# Altium schematic sheet PerifericaRelè.SchDoc(PerifericaRelè)
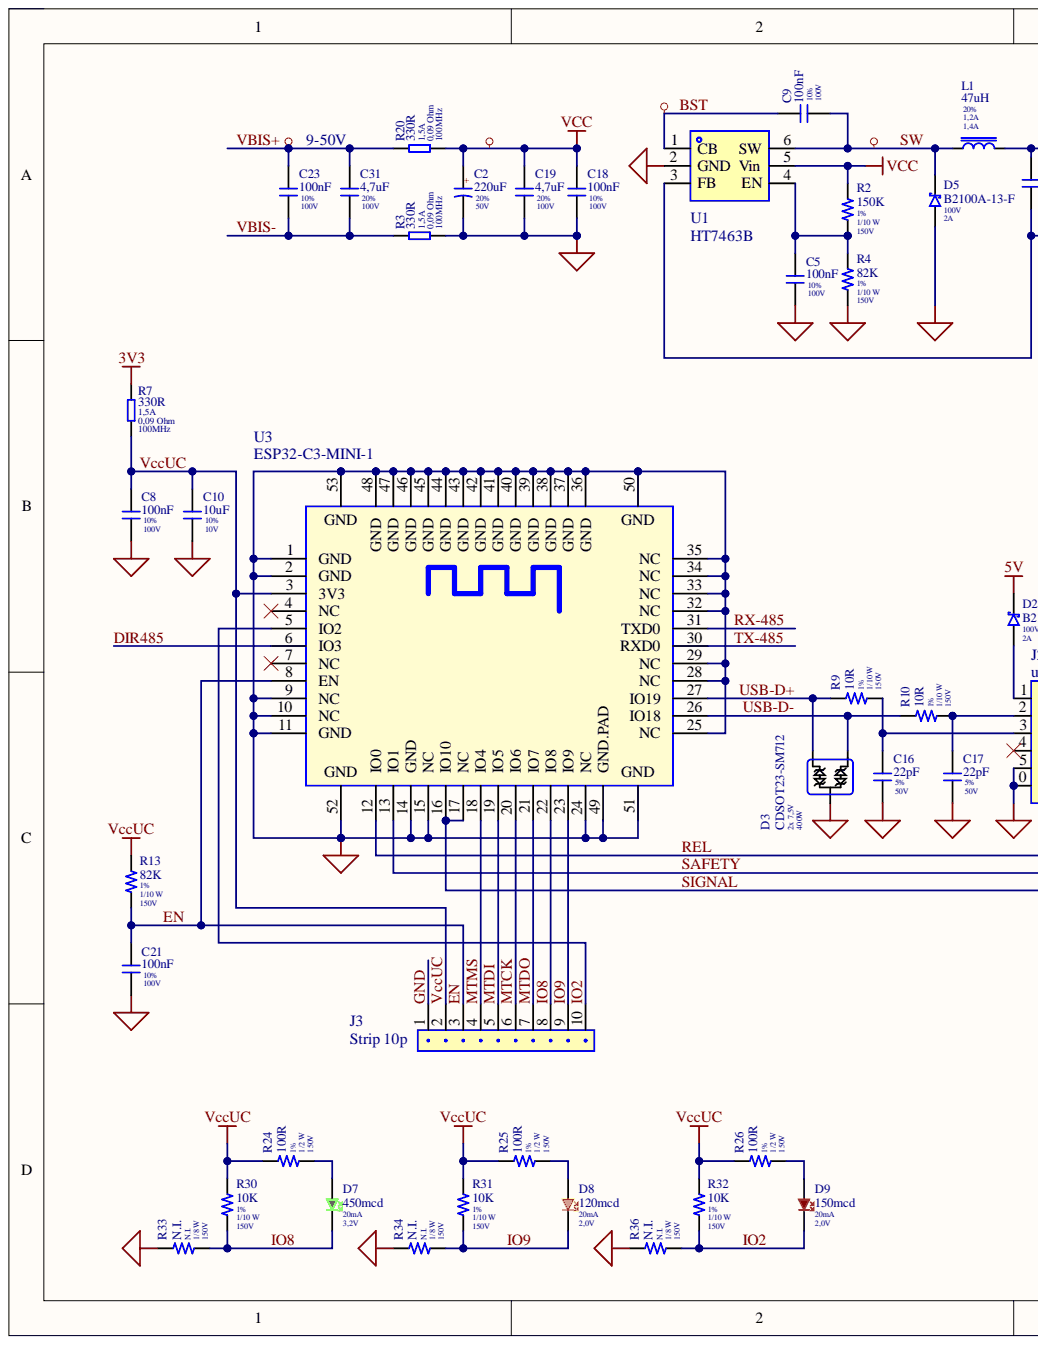
[diagram p0: PerifericaRelè.SchDoc, left]
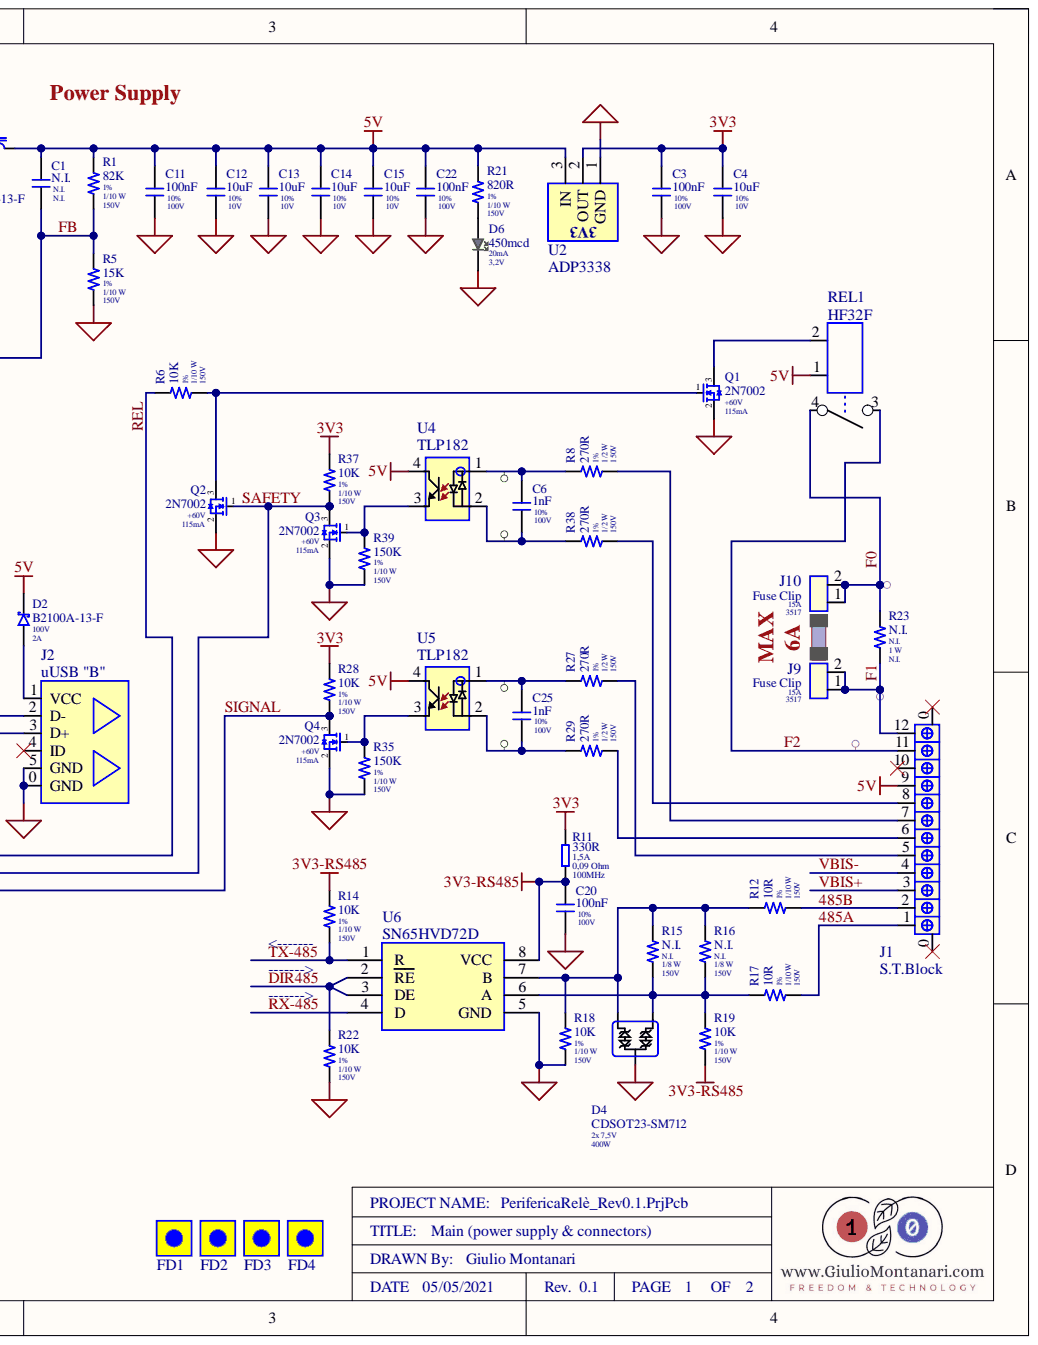
[diagram p1: PerifericaRelè.SchDoc, right]

## Parts
C1  N.I.  [CAP_0805]
C2  220uF  Tolerance: 20%  Voltage: 50V  [CAP_F_D8.0H10]
C3  100nF  Tolerance: 10%  Voltage: 100V  [CAP_0805]
C4  10uF  Tolerance: 10%  Voltage: 10V  [CAP_0805]
C5  100nF  Tolerance: 10%  Voltage: 100V  [CAP_0805]
C6  1nF  Tolerance: 10%  Voltage: 100V  [CAP_0805]
C8  100nF  Tolerance: 10%  Voltage: 100V  [CAP_0805]
C9  100nF  Tolerance: 10%  Voltage: 100V  [CAP_0805]
C10  10uF  Tolerance: 10%  Voltage: 10V  [CAP_0805]
C11  100nF  Tolerance: 10%  Voltage: 100V  [CAP_0805]
C12  10uF  Tolerance: 10%  Voltage: 10V  [CAP_0805]
C13  10uF  Tolerance: 10%  Voltage: 10V  [CAP_0805]
C14  10uF  Tolerance: 10%  Voltage: 10V  [CAP_0805]
C15  10uF  Tolerance: 10%  Voltage: 10V  [CAP_0805]
C16  22pF  Tolerance: 5%  Voltage: 50V  [CAP_0805]
C17  22pF  Tolerance: 5%  Voltage: 50V  [CAP_0805]
C18  100nF  Tolerance: 10%  Voltage: 100V  [CAP_0805]
C19  4,7uF  Tolerance: 20%  Voltage: 100V  [CAP_1210]
C20  100nF  Tolerance: 10%  Voltage: 100V  [CAP_0805]
C21  100nF  Tolerance: 10%  Voltage: 100V  [CAP_0805]
C22  100nF  Tolerance: 10%  Voltage: 100V  [CAP_0805]
C23  100nF  Tolerance: 10%  Voltage: 100V  [CAP_0805]
C25  1nF  Tolerance: 10%  Voltage: 100V  [CAP_0805]
C31  4,7uF  Tolerance: 20%  Voltage: 100V  [CAP_1210]
D2  B2100A-13-F  Current MAX: 2A  Voltage MAX: 100V  [DO-214AC]
D3  CDSOT23-SM712  Power: 400W  Voltage: 2x 7,5V  [SOT23]
D4  CDSOT23-SM712  [SOT23]
D5  B2100A-13-F  Current MAX: 2A  Voltage MAX: 100V  [DO-214AC]
D6  450mcd  Current: 20mA  Voltage: 3,2V  [LED_G_0805]
D7  450mcd  Current: 20mA  Voltage: 3,2V  [LED_G_0805]
D8  120mcd  Current: 20mA  Voltage: 2,0V  [LED_O_0805]
D9  150mcd  Current: 20mA  Voltage: 2,0V  [LED_R_0805]
FD1  Fiducial  [FIDUCIAL_TL]  (value not drawn)  (no BOM)
FD2  Fiducial  [FIDUCIAL_TL]  (value not drawn)  (no BOM)
FD3  Fiducial  [FIDUCIAL_TL]  (value not drawn)  (no BOM)
FD4  Fiducial  [FIDUCIAL_TL]  (value not drawn)  (no BOM)
J1  S.T.Block  [Screw_TBlock_12PIN_8.26mm_ME]
J2  uUSB "B"  [Micro_USB_B_SMD]
J3  Strip 10p  [STRIP_M_10P_2.54mm]
J9  Fuse Clip  Current: 15A  Man 1 P\N: 3517  [FuseClip_3517]
J10  Fuse Clip  Current: 15A  Man 1 P\N: 3517  [FuseClip_3517]
L1  Irms: 1,2A  Isat: 1,4A  Tolerance: 20%  [IND_6045]
Q1  2N7002  Current MAX: 115mA  Voltage MAX: +60V  [SOT23]
Q2  2N7002  Current MAX: 115mA  Voltage MAX: +60V  [SOT23]
Q3  2N7002  Current MAX: 115mA  Voltage MAX: +60V  [SOT23]
Q4  2N7002  Current MAX: 115mA  Voltage MAX: +60V  [SOT23]
R1  82K  Power Rate: 1/10 W  Tolerance: 1%  Work Voltage: 150V  [RES_0805]
R2  150K  Power Rate: 1/10 W  Tolerance: 1%  Work Voltage: 150V  [RES_0805]
R3  Current: 1,5A  DC Resistance: 0,09 Ohm  FRQ: 100MHz  Inductance: 330R  [FER_0805]  (hidden comment: Ferrite)
R4  82K  Power Rate: 1/10 W  Tolerance: 1%  Work Voltage: 150V  [RES_0805]
R5  15K  Power Rate: 1/10 W  Tolerance: 1%  Work Voltage: 150V  [RES_0805]
R6  10K  Power Rate: 1/10 W  Tolerance: 1%  Work Voltage: 150V  [RES_0805]
R7  Current: 1,5A  DC Resistance: 0,09 Ohm  FRQ: 100MHz  Inductance: 330R  [FER_0805]  (hidden comment: Ferrite)
R8  270R  Power Rate: 1/2 W  Tolerance: 1%  Work Voltage: 150V  [RES_0805]
R9  10R  Power Rate: 1/10 W  Tolerance: 1%  Work Voltage: 150V  [RES_0805]
R10  10R  Power Rate: 1/10 W  Tolerance: 1%  Work Voltage: 150V  [RES_0805]
R11  Current: 1,5A  FRQ: 100MHz  Inductance: 330R  [FER_0805]  (hidden comment: Ferrite)
R12  10R  Power Rate: 1/10 W  Tolerance: 1%  Work Voltage: 150V  [RES_0805]
R13  82K  Power Rate: 1/10 W  Tolerance: 1%  Work Voltage: 150V  [RES_0805]
R14  10K  Tolerance: 1%  Work Voltage: 150V  [RES_0805]
R15  N.I.  Power Rate: 1/8 W  Work Voltage: 150V  [RES_0805]
R16  N.I.  Power Rate: 1/8 W  Work Voltage: 150V  [RES_0805]
R17  10R  Power Rate: 1/10 W  Tolerance: 1%  Work Voltage: 150V  [RES_0805]
R18  10K  Power Rate: 1/10 W  Tolerance: 1%  Work Voltage: 150V  [RES_0805]
R19  10K  Power Rate: 1/10 W  Tolerance: 1%  Work Voltage: 150V  [RES_0805]
R20  Current: 1,5A  DC Resistance: 0,09 Ohm  FRQ: 100MHz  Inductance: 330R  [FER_0805]  (hidden comment: Ferrite)
R21  820R  Tolerance: 1%  Work Voltage: 150V  [RES_0805]
R22  10K  Power Rate: 1/10 W  Tolerance: 1%  Work Voltage: 150V  [RES_0805]
R23  N.I.  Power Rate: 1 W  [RES_2512]
R24  100R  Power Rate: 1/2 W  Tolerance: 1%  Work Voltage: 150V  [RES_0805]
R25  100R  Power Rate: 1/2 W  Tolerance: 1%  Work Voltage: 150V  [RES_0805]
R26  100R  Power Rate: 1/2 W  Tolerance: 1%  Work Voltage: 150V  [RES_0805]
R27  270R  Power Rate: 1/2 W  Tolerance: 1%  Work Voltage: 150V  [RES_0805]
R28  10K  Tolerance: 1%  Work Voltage: 150V  [RES_0805]
R29  270R  Power Rate: 1/2 W  Tolerance: 1%  Work Voltage: 150V  [RES_0805]
R30  10K  Power Rate: 1/10 W  Tolerance: 1%  Work Voltage: 150V  [RES_0805]
R31  10K  Power Rate: 1/10 W  Tolerance: 1%  Work Voltage: 150V  [RES_0805]
R32  10K  Power Rate: 1/10 W  Tolerance: 1%  Work Voltage: 150V  [RES_0805]
R33  N.I.  Power Rate: 1/8 W  Work Voltage: 150V  [RES_0805]
R34  N.I.  Power Rate: 1/8 W  Work Voltage: 150V  [RES_0805]
R35  150K  Power Rate: 1/10 W  Tolerance: 1%  Work Voltage: 150V  [RES_0805]
R36  N.I.  Power Rate: 1/8 W  Work Voltage: 150V  [RES_0805]
R37  10K  Tolerance: 1%  Work Voltage: 150V  [RES_0805]
R38  270R  Power Rate: 1/2 W  Tolerance: 1%  Work Voltage: 150V  [RES_0805]
R39  150K  Power Rate: 1/10 W  Tolerance: 1%  Work Voltage: 150V  [RES_0805]
REL1  HF32F  [REL_184x102h157]
U1  HT7463B  [SOT23-6]
U2  ADP3338  [SOT223]
U3  ESP32-C3-MINI-1  [ESP32-C3-MINI-1]
U4  TLP182  [SOP-4]
U5  TLP182  [SOP-4]
U6  SN65HVD72D  [SO-8]

## Nets
3V3: C3.1 C4.1 R7.1 R11.1 R28.1 R37.1 U2.2
3V3-RS485: C20.1 R11.2 R14.1 R19.2 U6.8(VCC)
5V: C1.1 C11.1 C12.1 C13.1 C14.1 C15.1 C22.1 D2.K J1.9 L1.2 R1.1 R21.1 REL1.1 U2.3 U4.4 U5.4
VCC: C2.1 C18.1 C19.1 R2.1 R20.2 U1.5(Vin)
485A: J1.1 R17.2
485B: J1.2 R12.2
BST: C9.1 U1.1(CB)
DIR485: R22.1 U3.6(IO3) U6.2(RE) U6.3(DE)
EN: C21.1 J3.3 R13.2 U3.8(EN)
F0: J10.1 J10.2 R23.2 REL1.4
F1: J1.12 J9.1 J9.2 R23.1
F2: J1.11 REL1.3
FB: C1.2 R1.2 R5.1 U1.3(FB)
GND: C2.2 C3.2 C4.2 C5.2 C8.2 C10.2 C11.2 C12.2 C13.2 C14.2 C15.2 C16.2 C17.2 C18.2 C19.2 C20.2 C21.2 C22.2 D3.3 D4.3 D5.A D6.K J2.0(GND) J2.5(GND) J3.1 Q1.2 Q2.2 Q3.2 Q4.2 R3.2 R4.2 R5.2 R18.2 R22.2 R33.1 R34.1 R35.2 R36.1 R39.2 U1.2(GND) U2.1 U3.1(GND) U3.2(GND) U3.9(NC) U3.10(NC) U3.11(GND) U3.14(GND) U3.15(NC) U3.24(NC) U3.25(NC) U3.28(NC) U3.29(NC) U3.32(NC) U3.33(NC) U3.34(NC) U3.35(NC) U3.36(GND) U3.37(GND) U3.38(GND) U3.39(GND) U3.40(GND) U3.41(GND) U3.42(GND) U3.43(GND) U3.44(GND) U3.45(GND) U3.46(GND) U3.47(GND) U3.48(GND) U3.49(GND.PAD) U3.50 U3.51 U3.52 U3.53 U6.5(GND)
IO2: D9.K J3.10 R32.2 R36.2 U3.5(IO2)
IO8: D7.K J3.8 R30.2 R33.2 U3.22(IO8)
IO9: D8.K J3.9 R31.2 R34.2 U3.23(IO9)
MTCK: J3.6 U3.20(IO6)
MTDI: J3.5 U3.19(IO5)
MTDO: J3.7 U3.21(IO7)
MTMS: J3.4 U3.18(IO4)
REL: R6.1 U3.12(IO0)
RX-485: U3.31(TXD0) U6.4(D)
SAFETY: Q2.1 Q3.3 R37.2 U3.13(IO1)
SIGNAL: Q4.3 R28.2 U3.16(IO10) U3.17(NC)
SW: C9.2 D5.K L1.1 U1.6(SW)
TX-485: R14.2 U3.30(RXD0) U6.1(R)
USB-D+: D3.1 R9.1 U3.27(IO19)
USB-D-: D3.2 R10.1 U3.26(IO18)
VBIS+: C23.1 C31.1 J1.3 R20.1
VBIS-: C23.2 C31.2 J1.4 R3.1
VccUC: C8.1 C10.1 J3.2 R7.2 R13.1 R24.1 R25.1 R26.1 R30.1 R31.1 R32.1 U3.3(3V3)
NetC5_1: C5.1 R2.2 R4.1 U1.4(EN)
NetC6_1: C6.1 R8.1 U4.1
NetC6_2: C6.2 R38.1 U4.2
NetC16_1: C16.1 J2.3(D+) R9.2
NetC17_1: C17.1 J2.2(D-) R10.2
NetC25_1: C25.1 R27.1 U5.1
NetC25_2: C25.2 R29.1 U5.2
NetD2_A: D2.A J2.1(VCC)
NetD4_1: D4.1 R12.1 R15.1 R16.1 R18.1 U6.7(B)
NetD4_2: D4.2 R15.2 R16.2 R17.1 R19.1 U6.6(A)
NetD6_A: D6.A R21.2
NetD7_A: D7.A R24.2
NetD8_A: D8.A R25.2
NetD9_A: D9.A R26.2
NetJ1_5: J1.5 R27.2
NetJ1_6: J1.6 R29.2
NetJ1_7: J1.7 R8.2
NetJ1_8: J1.8 R38.2
NetQ1_1: Q1.1 Q2.3 R6.2
NetQ1_3: Q1.3 REL1.2
NetQ3_1: Q3.1 R39.1 U4.3
NetQ4_1: Q4.1 R35.1 U5.3

Not connected: J1 0 10; J2 4(ID); U3 4(NC) 7(NC)

## Library data of the parts
C1 = N.I. 0805: Cap N.I. 0805; Database Table Name: Capacitors CERAMIC; Temp Range: -55°C to +125°C; Capacitance: N.I.; Component Kind: Standard; Library Name: Database_Libs.DbLib; Part Number: N.I. 0805; Price 1: 0; Tolerance: N.I.; Voltage: N.I.
C2 = COES 212075010227: Cap Alum Poly 220uF+/-20%; 50V; Case 8,0x10mm; 2000Hrs@105°C; Manufactuer 1: Panasonic; Manufactuer 2: Nichicon; Database Table Name: Capacitors ELECTROLYTIC; Man 1 P\N: EEE-FT1H221AP; Man 2 P\N: UCM1H221MNL1GS; Mounting Method: SMD; Temp Range: -55°C to +105°C; Capacitance: 220uF; Component Kind: Standard; ComponentLink1Description: Data Scheet Panasonic; ComponentLink2Description: Data Scheet Nichicon; Library Name: Database_Libs.DbLib; Part Number: COES 212075010227; Price 1: 0,447; Price 2: 0,488; ComponentLink1URL: C:\Sharing\Lib ALTIUM\DataBaseLib\DataScheet\Condensatori Elettrolitici\Panasonic_CapPoly_SMD_FT-V.pdf; ComponentLink2URL: C:\Sharing\Lib ALTIUM\DataBaseLib\DataScheet\Condensatori Elettrolitici\Nichicon_UCM.pdf
C3 C5 C8 C9 C11 C18 C20-C23 = COCS 557061010104: Cap 100nF+/-10%;  100V; Case 0805; X7R; Manufactuer 1: Samsung; Manufactuer 2: AVX; Manufactuer 3: Walsin; Database Table Name: Capacitors CERAMIC; Man 1 P\N: CL21B104KBCNNNC; Man 2 P\N: 08051C104KAT2A; Man 3 P\N: 0805B104K101CT; Mounting Method: SMD; Temp Range: -55°C to +125°C; Capacitance: 100nF; Component Kind: Standard; ComponentLink1Description: Data Scheet Samsung; ComponentLink2Description: Data Scheet AVX; ComponentLink3Description: Data Scheet Walsin; Library Name: Database_Libs.DbLib; Part Number: COCS 557061010104; Price 1: 0,0092; Price 2: 0,059; Price 3: 0,056; ComponentLink1URL: C:\Sharing\Lib ALTIUM\DataBaseLib\DataScheet\Condensatori Ceramici\Samsung_CL.pdf; ComponentLink2URL: C:\Sharing\Lib ALTIUM\DataBaseLib\DataScheet\Condensatori Ceramici\AVX_X7R.pdf; ComponentLink3URL: C:\Sharing\Lib ALTIUM\DataBaseLib\DataScheet\Condensatori Ceramici\Walsing_MMLC.pdf
C4 C10 C12-C15 = COCS 557061000106: Cap 10uF+/-10%;  10V; Case 0805; X5R; Manufactuer 1: Samsung Electro-Mechanics; Manufactuer 2: Taiyo Yoden  Taiyo Yuden; Manufactuer 3: Walsin; Database Table Name: Capacitors CERAMIC; Man 1 P\N: CL21A106KPFNNNE; Man 2 P\N: LMK212ABJ106KG-T; Man 3 P\N: 0805X106K100CT; Mounting Method: SMD; Temp Range: -55°C to +125°C; Capacitance: 10uF; Component Kind: Standard; ComponentLink1Description: Data Scheet Samsung; ComponentLink2Description: Data Scheet Taiyo Yoden; ComponentLink3Description: Data Scheet Walsin; Library Name: Database_Libs.DbLib; Part Number: COCS 557061000106; Price 1: 0,0139; Price 2: 0,0147; Price 3: 0,0216; ComponentLink1URL: C:\Sharing\Lib ALTIUM\DataBaseLib\DataScheet\Condensatori Ceramici\Samsung_CL.pdf; ComponentLink2URL: C:\Sharing\Lib ALTIUM\DataBaseLib\DataScheet\Condensatori Ceramici\TaiyoYuden_LMK212ABJ106KG-T.pdf; ComponentLink3URL: C:\Sharing\Lib ALTIUM\DataBaseLib\DataScheet\Condensatori Ceramici\Walsing_MMLC.pdf
C6 C25 = COCS 557061010102: Cap 1nF+/-10%;  100V; Case 0805; X7R; Manufactuer 1: Johanson; Manufactuer 2: AVX; Manufactuer 3: Walsin; Database Table Name: Capacitors CERAMIC; Man 1 P\N: 101R15W102KV4T; Man 2 P\N: 08051C102KAT2A; Man 3 P\N: 0805B102K101CT; Mounting Method: SMD; Temp Range: -55°C to +125°C; Capacitance: 1nF; Component Kind: Standard; ComponentLink1Description: Datascheet Johanson; ComponentLink2Description: Datascheet AVX; ComponentLink3Description: Data Scheet Walsin; Library Name: Database_Libs.DbLib; Part Number: COCS 557061010102; Price 1: 0,0034; Price 2: 0,02; Price 3: 0,035; ComponentLink1URL: C:\Sharing\Lib ALTIUM\DataBaseLib\DataScheet\Condensatori Ceramici\Johanson_MLCC.pdf; ComponentLink2URL: C:\Sharing\Lib ALTIUM\DataBaseLib\DataScheet\Condensatori Ceramici\AVX_X7R.pdf; ComponentLink3URL: C:\Sharing\Lib ALTIUM\DataBaseLib\DataScheet\Condensatori Ceramici\Walsing_MMLC.pdf
C16 C17 = COCS 557065000220: Cap 22pF+/-5%;  50V; Case 0805; COG; Manufactuer 1: Samsung; Manufactuer 2: AVX; Manufactuer 3: Walsin; Database Table Name: Capacitors CERAMIC; Man 1 P\N: CL21C220JB61PNC; Man 2 P\N: 08055A220JAT2A; Man 3 P\N: 0805N220J500CT; Mounting Method: SMD; Temp Range: -55°C to +125°C; Capacitance: 22pF; Component Kind: Standard; ComponentLink1Description: Data Scheet Samsung; ComponentLink2Description: Data Scheet AVX; ComponentLink3Description: Data Scheet Walsin; Library Name: Database_Libs.DbLib; Part Number: COCS 557065000220; Price 1: 0,028; Price 2: 0,0182; Price 3: 0,023; ComponentLink1URL: C:\Sharing\Lib ALTIUM\DataBaseLib\DataScheet\Condensatori Ceramici\Samsung_CL.pdf; ComponentLink2URL: C:\Sharing\Lib ALTIUM\DataBaseLib\DataScheet\Condensatori Ceramici\AVX_C0G_NP0.pdf; ComponentLink3URL: C:\Sharing\Lib ALTIUM\DataBaseLib\DataScheet\Condensatori Ceramici\Walsing_MMLC.pdf
C19 C31 = COCS 552A71010475: Cap 4,7uF+/-20%;  100V; Case 1210; X7S; Manufactuer 1: Taiyo Yuden; Manufactuer 2: TDK; Manufactuer 3: PSA; Database Table Name: Capacitors CERAMIC; Man 1 P\N: HMK325C7475KN-TE; Man 2 P\N: C3225X7S2A475KT000N; Man 3 P\N: FS32X475K101EGG  FS32X475K101EGG; Mounting Method: SMD; Temp Range: -55°C to +125°C; Capacitance: 4,7uF; Component Kind: Standard; ComponentLink1Description: Datascheet; ComponentLink2Description: Data Scheet TDK; ComponentLink3Description: Data Scheet PSA; Library Name: Database_Libs.DbLib; Part Number: COCS 552A71010475; Price 1: 0,1256; Price 2: 0,3084; Price 3: 0,2297; ComponentLink1URL: C:\Sharing\Lib ALTIUM\DataBaseLib\DataScheet\Condensatori Ceramici\TaiyoYuden_LMK212ABJ106KG-T.pdf; ComponentLink2URL: C:\Sharing\Lib ALTIUM\DataBaseLib\DataScheet\Condensatori Ceramici\TDK_Cseries.pdf; ComponentLink3URL: C:\Sharing\Lib ALTIUM\DataBaseLib\DataScheet\Condensatori Ceramici\PSA-Prosperity-Dielectrics.pdf
D2 D5 = DIOS 2150000B2100A: Schottky Diode B2100A-13-F; Vmax 100V; Imax 2A; DO-214AC (SMA); Manufactuer 1: DIODES; Manufactuer 2: ON Semiconductor; Database Table Name: Diode Rectifire; Man 2 P\N: MBRA2H100T3G; Mounting Method: SMD; Temp Range: -°C to +°C; COD: B2100A-13-F; Component Kind: Standard; ComponentLink1Description: Data Scheet Diodes; ComponentLink2Description: Data Scheet ON Semiconductor; Library Name: Database_Libs.DbLib; Man 1 P\N: B2100A-13-F; Part Number: DIOS 2150000B2100A; Price 1: 0,2001; Price 2: 0,6143; ComponentLink1URL: C:\Sharing\Lib ALTIUM\DataBaseLib\DataScheet\Diode\Diodes_B2100A.pdf; ComponentLink2URL: C:\Sharing\Lib ALTIUM\DataBaseLib\DataScheet\Diode\OnSemi_MBRS2H100-D.PDF
D3 = ZENS 52350STO23SM712: Diode TVS; ESD Prot MAX 30KV; Vbr 2x7,5V; Ppk 400W; Manufactuer 1: Bourns; Database Table Name: Diode ZENER/ESD; Mounting Method: SMD; Tolerance: 10%; COD: CDSOT23-SM712; Component Kind: Standard; ComponentLink1Description: Datascheet Bourns; Library Name: Database_Libs.DbLib; Man 1 P\N: CDSOT23-SM712; Part Number: ZENS 52350STO23SM712; Price 1: 0,55; ComponentLink1URL: C:\Sharing\Lib ALTIUM\DataBaseLib\DataScheet\Diode\DSOT23-SM712.pdf
D4 = ZENS 52350STO23SM712: Diode TVS; ESD Prot MAX 30KV; Vbr 2x7,5V; Ppk 400W; Manufactuer 1: Bourns; Database Table Name: Diode ZENER/ESD; Mounting Method: SMD; Tolerance: 10%; Voltage: 2x 7,5V; COD: CDSOT23-SM712; Component Kind: Standard; ComponentLink1Description: Datascheet Bourns; Library Name: Database_Libs.DbLib; Man 1 P\N: CDSOT23-SM712; Part Number: ZENS 52350STO23SM712; Power: 400W; Price 1: 0,55; ComponentLink1URL: C:\Sharing\Lib ALTIUM\DataBaseLib\DataScheet\Diode\DSOT23-SM712.pdf
D6 D7 = LEDS 9AC080GS7500: LED Green Waterclear; Case 0805; Vf 3,2V; If 20mA; 450mcd; Manufactuer 1: Wurth Elektronik; Database Table Name: Diode LED; Man 1 P\N: 150080GS75000; Mounting Method: SMD; Temp Range: -40°C to +85°C; Brightness: 450mcd; Component Kind: Standard; ComponentLink1Description: Datascheet WE; Library Name: Database_Libs.DbLib; Part Number: LEDS 9AC080GS7500; Price 1: 0,113; ComponentLink1URL: C:\Sharing\Lib ALTIUM\DataBaseLib\DataScheet\Diode\LED_G_150080GS75000.pdf
D8 = LEDS DAC080YS7500: LED Orange Waterclear; Case 0805; Vf 2,0V; If 20mA; 120mcd; Manufactuer 1: Wurth Elektronik; Database Table Name: Diode LED; Man 1 P\N: 150080YS75000; Mounting Method: SMD; Temp Range: -40°C to +85°C; Brightness: 120mcd; Component Kind: Standard; ComponentLink1Description: Datascheet WE; Library Name: Database_Libs.DbLib; Part Number: LEDS DAC080YS7500; Price 1: 0,112; ComponentLink1URL: C:\Sharing\Lib ALTIUM\DataBaseLib\DataScheet\Diode\LED_O_150080YS75000.pdf
D9 = LEDS 2AC080RS7500: LED Red Waterclear; Case 0805; Vf 2,0V; If 20mA; 150mcd; Manufactuer 1: Wurth Elektronik; Database Table Name: Diode LED; Man 1 P\N: 150080RS75000; Mounting Method: SMD; Temp Range: -40°C to +85°C; Brightness: 150mcd; Component Kind: Standard; ComponentLink1Description: Datascheet WE; Library Name: Database_Libs.DbLib; Part Number: LEDS 2AC080RS7500; Price 1: 0,106; ComponentLink1URL: C:\Sharing\Lib ALTIUM\DataBaseLib\DataScheet\Diode\LED_R_150080RS75000.pdf
FD1-FD4 = Fiducial: Reference P&P; Library Name: Mechanical.SchLib
J1 = S.T.B_12PIN_15A_300V_8.26mm: Screw Terminal Block; 12 PIN; 15A; 300V; Manufactuer 1: Molex; Part Number: MOR STB15A30012P; Man 1 P\N: 38700-6112; Mounting Method: PTH; Component Kind: Standard; ComponentLink2Description: Datascheet Molex; ComponentLink3Description: Web Linck; ComponentLink3URL: https://www.molex.com/molex/products/part-detail/terminal_blocks/0387006112; Library Name: Connectors.SchLib; Price 1: 3.03; ComponentLink2URL: C:\Sharing\Lib ALTIUM\Simple\DataScheet\Connectors\Molex_STB_MTG_8.26mm
J2 = USB 2.0 Tipo Micro B: Micro USB 2.0 Tipo B Presa Connettore 5 P; Manufactuer 1: Amphenol; Part Number: MORS 0101181920001LF; Man 1 P\N: 10118192-0001LF; Cod. DigiKey: 609-4613-2-ND; Component Kind: Standard; ComponentLink1Description: Datascheet Amphenol; Library Name: Connectors.SchLib; Price: 0,311; ComponentLink1URL: C:\Sharing\Lib ALTIUM\Simple\DataScheet\Connectors\USB\USB_Micro_Amphenol.pdf
J3 = Conn_M_10P_1A_2.54mm: STRIP Vertical Header; Conn Male; PTH Mount; 10pol; Imax 1A; Vmax 100V; Pich 100mil; Part Number: CON 0001A1011010; Component Kind: Standard; I max: 1A; Library Name: Connectors.SchLib; Vmax: 100V
J9 J10 = Cartridge Fuse Clip: Cartridge Fuse Clip; 15A; Fuse Size Held:5mm; Pich 6.76/23.11mm; Manufactuer 1: KEYSTONE; Part Number: CON 000000003517; Mounting Method: PTH; Component Kind: Standard; Library Name: Connectors.SchLib; Price 1: 0,2480
L1 = IMPS 757371420470: Power. Ind. 6,0X6,0 H4,5mm; Ind.47uH; Irms 1,2A; Isat 1,4A;; Manufactuer 1: CENKER  SCE; Manufactuer 2: WE; Database Table Name: Inductance POW; Inductance: 47uH; Man 1 P\N: CKCS6045-47uH/M; Man 2 P\N: 74404064470; Mounting Method: SMD; Component Kind: Standard; ComponentLink1Description: Datascheet CENKER; ComponentLink2Description: Datascheet WE; Library Name: Database_Libs.DbLib; Part Number: IMPS 757371420470; Price 1: 0,0483; Price 2: 0,864; ComponentLink1URL: C:\Sharing\Lib ALTIUM\DataBaseLib\DataScheet\Inductor\CENKER-CKCS.pdf; ComponentLink2URL: C:\Sharing\Lib ALTIUM\DataBaseLib\DataScheet\Inductor\WE_74404064470.pdf
Q1-Q4 = TRXS 110FD2N7002: Small Singan N-FET; Vds+60V; Id+115A; Vgs +/-20V; Vthmax 2,5V; Case SOT23; Manufactuer 1: ON Semiconductor; Database Table Name: Transistor; Man 1 P\N: 2N7002LT1G; Mounting Method: SMD; COD: 2N7002; Component Kind: Standard; ComponentLink1Description: Data ScheetOnSemi; Library Name: Database_Libs.DbLib; Part Number: TRXS 110FD2N7002; Price 1: 0,0708; Vgs: +/- 20V; ComponentLink1URL: C:\Sharing\Lib ALTIUM\DataBaseLib\DataScheet\Transistor\OnSemi_2N7002L-D.PDF
R1 R4 R13 = RESS 4170310B0823: Res Thick Film; 82K+/-1%;  1/10W; Case 0805; 150V; Manufactuer 1: Kamaya; Man 1 P\N: RMC1/10823FTP; Mounting Method: SMD; Component Kind: Standard; ComponentLink1Description: Datascheet Kamaya; ComponentLink1URL: Datascheet\Resistors\Kamaya_RMC.pdf; Database Table Name: Resistors 0805; Library Name: Database_Libs.DbLib; Part Number: RESS 4170310B0823; Price 1: 0,02; Resistance: 82K
R2 R35 R39 = RESS 4170310B0154: Res Thick Film; 150K+/-1%;  1/10W; Case 0805; 150V; Manufactuer 1: Kamaya; Man 1 P\N: RMC1/10154FTP; Mounting Method: SMD; Component Kind: Standard; ComponentLink1Description: Datascheet Kamaya; ComponentLink1URL: Datascheet\Resistors\Kamaya_RMC.pdf; Database Table Name: Resistors 0805; Library Name: Database_Libs.DbLib; Part Number: RESS 4170310B0154; Price 1: 0,02; Resistance: 150K
R3 R7 R11 R20 = INPS 718052010221: Fer 330@100MHz; 0,09ohm;  1,5A; Case 0805;; Manufactuer 1: Murata; Database Table Name: Ferriti SMD; Man 1 P\N: BLM21PG331SN1; Man 3 P\N: LQW18ANR22J8ZD; Mounting Method: SMD; Component Kind: Standard; ComponentLink1Description: Murata Datascheet; Library Name: Database_Libs.DbLib; Part Number: INPS 718052010221; Price 1: 0,2; ComponentLink1URL: C:\Sharing\Lib ALTIUM\DataBaseLib\DataScheet\Ferrite\Burata_BLM.pdf
  R11: DC Resistance: 0,09 Ohm
R5 = RESS 4170310B0153: Res Thick Film; 15K+/-1%;  1/10W; Case 0805; 150V; Manufactuer 1: Kamaya; Man 1 P\N: RMC1/10153FTP; Mounting Method: SMD; Component Kind: Standard; ComponentLink1Description: Datascheet Kamaya; ComponentLink1URL: Datascheet\Resistors\Kamaya_RMC.pdf; Database Table Name: Resistors 0805; Library Name: Database_Libs.DbLib; Part Number: RESS 4170310B0153; Price 1: 0,02; Resistance: 15K
R6 R14 R18 R19 R22 R28 R30-R32 R37 = RESS 4170310B0103: Res Thick Film; 10K+/-1%;  1/10W; Case 0805; 150V; Manufactuer 1: Kamaya; Man 1 P\N: RMC1/10103FTP; Mounting Method: SMD; Component Kind: Standard; ComponentLink1Description: Datascheet Kamaya; ComponentLink1URL: Datascheet\Resistors\Kamaya_RMC.pdf; Database Table Name: Resistors 0805; Library Name: Database_Libs.DbLib; Part Number: RESS 4170310B0103; Resistance: 10K
  R6: Price 1: 0,02
  R14: Power Rate: 1/10 W; Price 1: 0
  R18 R19 R22 R30-R32: Price 1: 0
  R28 R37: Power Rate: 1/10 W; Price 1: 0,02
R8 R27 R29 R38 = RESS 4170350B0271: Res Thick Film; 270R+/-1%;  1/2W; Case 0805; 150V; Manufactuer 1: Vishay / Dale; Manufactuer 2: Panasonic; Man 1 P\N: CRCW0805270RFKEAHP; Man 2 P\N: ERJ-P06F2700V; Mounting Method: SMD; Component Kind: Standard; ComponentLink1Description: Datascheet Vishay; ComponentLink1URL: Datascheet\Resistors\Vishay_CRCW-HP.pdf; ComponentLink2Description: Datascheet Panasonic; ComponentLink2URL: Datascheet\Resistors\Panasonic_ERJP.pdf; Database Table Name: Resistors 0805; Library Name: Database_Libs.DbLib; Part Number: RESS 4170350B0271; Price 1: 0,079; Price 2: 0,031; Resistance: 270R
R9 R10 R12 R17 = RESS 4170310B0100: Res Thick Film; 10R+/-1%;  1/10W; Case 0805; 150V; Manufactuer 1: Kamaya; Man 1 P\N: RMC1/10100FTP; Mounting Method: SMD; Component Kind: Standard; ComponentLink1Description: Datascheet Kamaya; ComponentLink1URL: Datascheet\Resistors\Kamaya_RMC.pdf; Database Table Name: Resistors 0805; Library Name: Database_Libs.DbLib; Part Number: RESS 4170310B0100; Price 1: 0; Resistance: 10R
R15 R16 R33 R34 R36 = N.I.: N.I.;  1/8W; Case 0805; 150V; Mounting Method: SMD; Component Kind: Standard; Database Table Name: Resistors 0805; Library Name: Database_Libs.DbLib; Part Number: N.I.; Price 1: 0; Resistance: N.I.; Tolerance: N.I.
R21 = RESS 4170310B0821: Res Thick Film; 820R+/-1%;  1/10W; Case 0805; 150V; Manufactuer 1: Kamaya; Man 1 P\N: RMC1/10821FTP; Mounting Method: SMD; Component Kind: Standard; ComponentLink1Description: Datascheet Kamaya; ComponentLink1URL: Datascheet\Resistors\Kamaya_RMC.pdf; Database Table Name: Resistors 0805; Library Name: Database_Libs.DbLib; Part Number: RESS 4170310B0821; Power Rate: 1/10 W; Price 1: 0,02; Resistance: 820R
R23 = N.I. 2512: Res N.I. 2512; Component Kind: Standard; Database Table Name: Resistors 2512; Library Name: Database_Libs.DbLib; Part Number: N.I. 2512; Resistance: N.I.; Tolerance: N.I.; Work Voltage: N.I.
R24-R26 = RESS 4170350B0101: Res Thick Film; 100R+/-1%;  1/2W; Case 0805; 150V; Manufactuer 1: Vishay / Dale; Manufactuer 2: Panasonic; Man 1 P\N: CRCW0805100RFKEAHP; Man 2 P\N: ERJ-P06F1000V; Mounting Method: SMD; Component Kind: Standard; ComponentLink1Description: Datascheet Vishay; ComponentLink1URL: Datascheet\Resistors\Vishay_CRCW-HP.pdf; ComponentLink2Description: Datascheet Panasonic; ComponentLink2URL: Datascheet\Resistors\Panasonic_ERJP.pdf; Database Table Name: Resistors 0805; Library Name: Database_Libs.DbLib; Part Number: RESS 4170350B0101; Price 1: 0,079; Price 2: 0,031; Resistance: 100R
REL1 = HF32F SPST-NO Vcoin 5V: Relay SPST-NO 10A 250VAC; Coil 5VDC; Case 18.4x10.2 H15.7; Manufactuer 1: NHLC; Manufactuer 2: HF; Manufactuer 3: TE Connectivity; Man 1 P\N: 932-5VDC-SL-A 10A/0.2W; Man 2 P\N: HF32F/005-HS; Man 3 P\N: 1461405-3; Mounting Method: PTH; Component Kind: Standard; ComponentLink1Description: Datascheet HF; ComponentLink2Description: Datascheet NHLC; ComponentLink3Description: Datascheet TE; Library Name: Relay.SchLib; Price 1: 0,31; Price 2: 0,319; Price 3: 0,85; ComponentLink1URL: C:\Sharing\Lib ALTIUM\Simple\DataScheet\Relay\HF_HF32F-005-HS_C192283.pdf; ComponentLink2URL: C:\Sharing\Lib ALTIUM\Simple\DataScheet\Relay\NHLC-932.pdf; ComponentLink3URL: C:\Sharing\Lib ALTIUM\Simple\DataScheet\Relay\TE-Connectivity-OEG.pdf
U1 = ADJ HT7463B: Asynchronous Step Down Converter; InPut 4.5-52V 600mA; Out 1.0-0.9xVin; Frq Fix 550KHz; Case SOT23-6; Manufactuer 1: Holtek; Manufactuer 2: Texsas Instruments; Part Number: INGS 64000000HT7463B; Man 2 P\N: LV2862XLVDDCR; Mounting Method: SMD; Component Kind: Standard; ComponentLink1Description: Datascheet Holtek; ComponentLink2Description: Datascheet TI; Library Name: PowerSupply.SchLib; Man 1 P\N: HT7463B; Price 1: 0,2; Price 2: 1,06; ComponentLink1URL: C:\Sharing\Lib ALTIUM\Simple\DataScheet\Power Supply\Holtek_HT7463A.pdf; ComponentLink2URL: C:\Sharing\Lib ALTIUM\Simple\DataScheet\Power Supply\TI_LV2862.pdf
U2 = 3V3 ADP3338: ADP3338 UltraLOW-IQ; LDO Reg; 1A 3.3Vout; Case SOT223; Manufactuer 1: Analog Devices; Part Number: INGS 118000ADP3383V3; Man 1 P\N: ADP3338AKCZ-3.3RL7; Man 2 P\N: ADP3338AKCZ-3.3-RL; Mounting Method: SMD; Component Kind: Standard; ComponentLink1Description: Datascheet Analog Device; Library Name: PowerSupply.SchLib; Manufactuer 2: Analog Devices; Price 1: 1,4900; Price 2: 1,2900; ComponentLink1URL: C:\Sharing\Lib ALTIUM\Simple\DataScheet\Power Supply\ADP3338_Analog.pdf
U3 = ESP32-C3-MINI-1: ESP32-C3-MINI-1 Small­sized 2.4 GHz Wi­Fand Bluetooth 5 module; RISC­V single­core uC ESP32­C3; 4 MB flash; 15 GPIOs; On­board PCB antenna; Manufactuer 1: Espressif Systems; Part Number: INGS 000000ESP32C3M1; Man 1 P\N: ESP32-C3-MINI-1-H4; Mounting Method: SMD; Component Kind: Standard; ComponentLink1Description: Datascheet Espressif; ComponentLink2Description: Core_ESP32_DataScheet; Library Name: Microcontroller.SchLib; Price 1: 1,61; ComponentLink1URL: C:\Sharing\Lib ALTIUM\Simple\DataScheet\uC\ESP32-C3-MINI-1_Datasheet.pdf; ComponentLink2URL: C:\Sharing\Lib ALTIUM\Simple\DataScheet\uC\ESP32-C3_Datasheet.pdf
U4 U5 = TLP182: Infrared LED & Photo Transistor; Manufactuer 1: TOSHIBA; Mounting Method: SMD; Component Kind: Standard; ComponentLink1Description: Datascheet Toshiba; Library Name: OptoPart.SchLib; Man 1 P\N: TLP182; Price 1: 0,1260; ComponentLink1URL: C:\Sharing\Lib ALTIUM\Simple\DataScheet\TLP182_Toshiba.pdf
U6 = SN65HVD72D: RS485 3v3 transceiver; Manufactuer 1: Texas Instruments; Part Number: INGS 00000SN65HVD72D; Mounting Method: SMD; Component Kind: Standard; ComponentLink1Description: Datascheet; Library Name: Integrated.SchLib; Man 1 P\N: SN65HVD72D; Price 1: 1,7200 €; ComponentLink1URL: C:\Sharing\Lib ALTIUM\Simple\DataScheet\sn65hvd72.pdf
